# Altium schematic sheet sensor_wifi.SchDoc
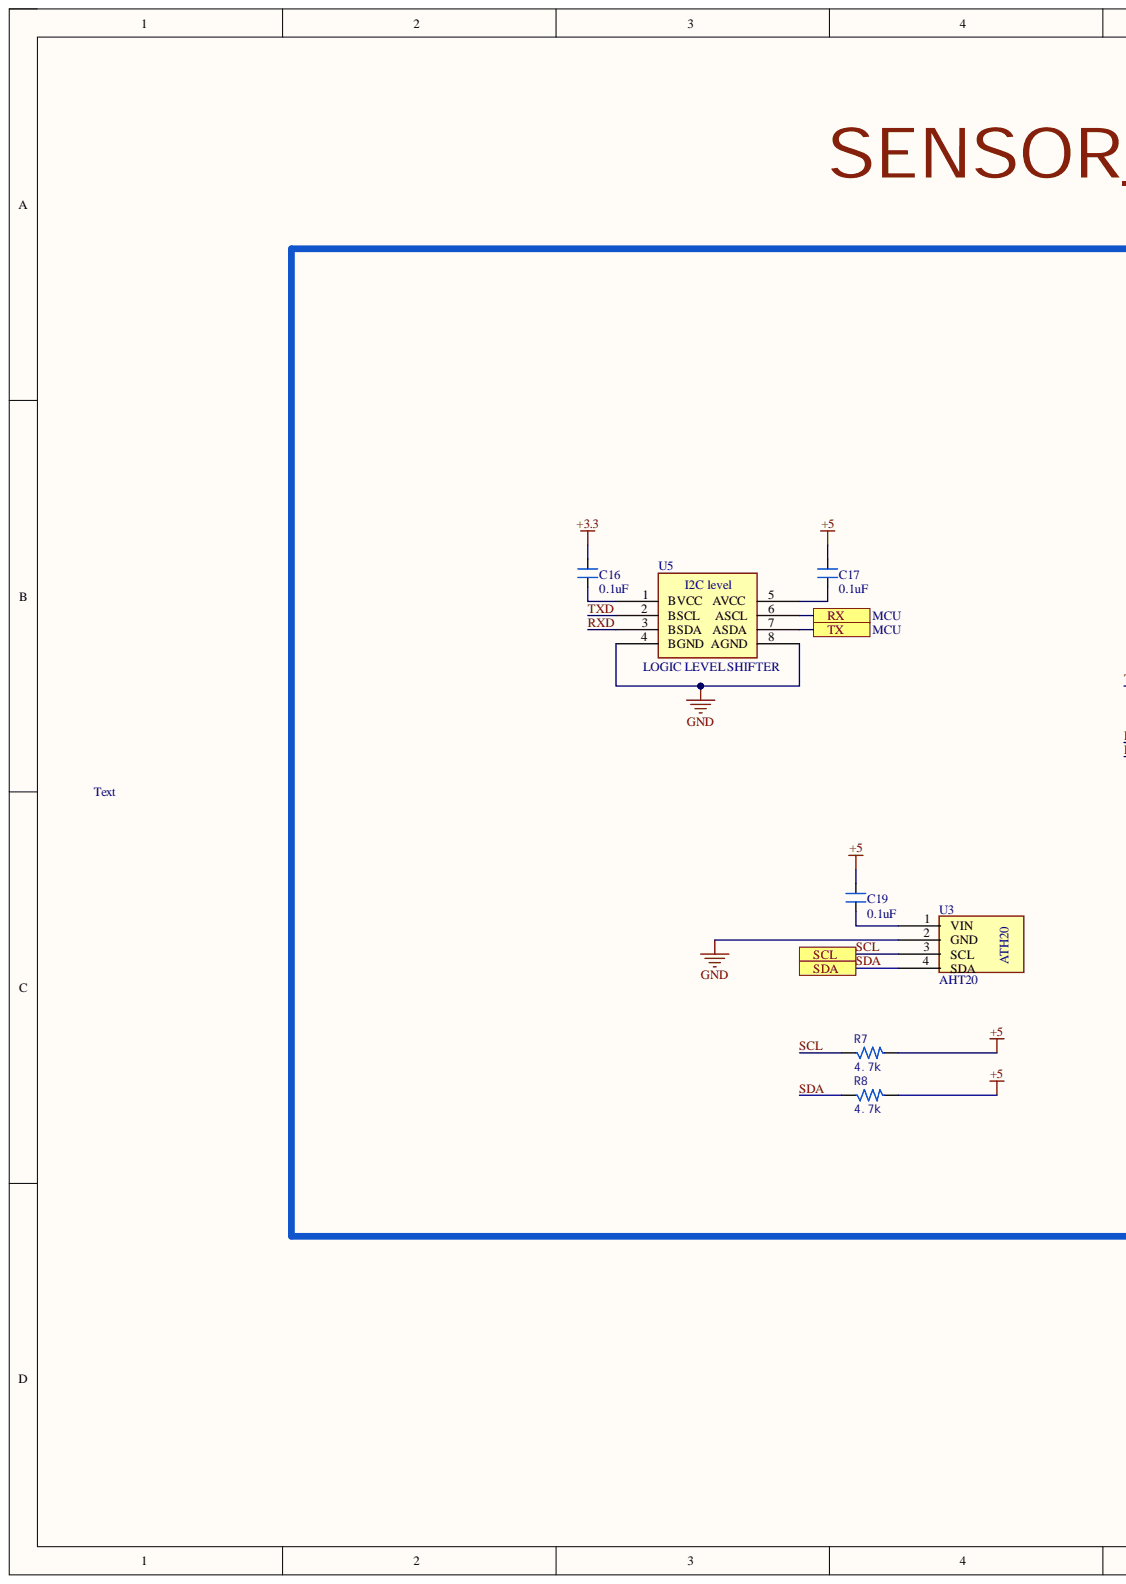
[diagram p0: sensor_wifi.SchDoc, left]
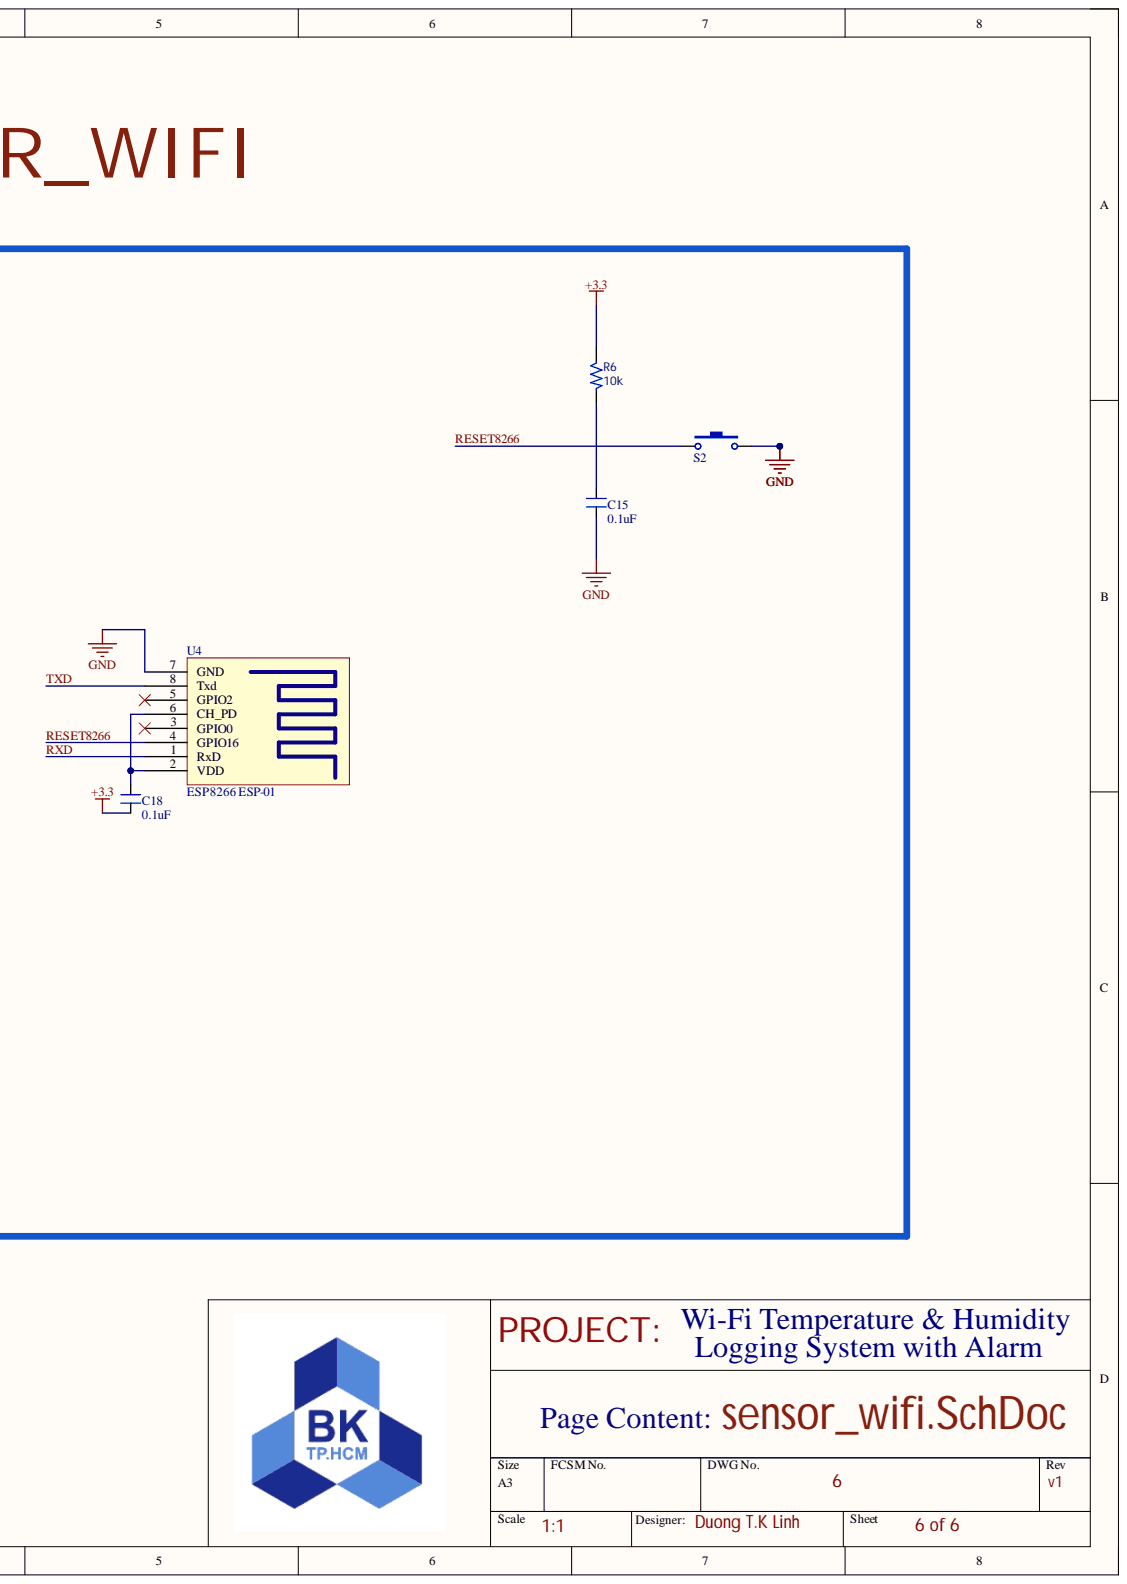
[diagram p1: sensor_wifi.SchDoc, right]

## Parts
C15  0.1uF  [CN2]
C16  0.1uF  [CN2]
C17  0.1uF  [CN2]
C18  0.1uF  [CN2]
C19  0.1uF  [CN2]
R6  10k  [RESISTOR_0603]
R7  4.7k  [RESISTOR_0603]
R8  4.7k  [RESISTOR_0603]
S2  RESET_WIFI  [BUTTON  ROUND]  (value not drawn)
U3  AHT20  [AHT20]
U4  ESP8266 ESP-01  [ESP8266 ESP-01]
U5  LOGIC LEVEL SHIFTER  [I2C LEVEL]

## Ports
RX, SCL, SDA, TX

## Nets
+3.3: C16.2 C18.1 R6.1
+5: C17.2 C19.2 R7.2 R8.2
GND: C15.1 S2.2 U3.2(GND) U4.7(GND) U5.4(BGND) U5.8(AGND)
RESET8266: S2.1 U4.4(GPIO16)
RX: U5.6(ASCL) ; port RX
RXD: U4.1(RxD) U5.3(BSDA)
SCL: R7.1 U3.3(SCL) ; port SCL
SDA: R8.1 U3.4(SDA) ; port SDA
TX: U5.7(ASDA) ; port TX
TXD: U4.8(Txd) U5.2(BSCL)
NetC15_2: C15.2 R6.2
NetC16_1: C16.1 U5.1(BVCC)
NetC17_1: C17.1 U5.5(AVCC)
NetC18_2: C18.2 U4.2(VDD) U4.6(CH_PD)
NetC19_1: C19.1 U3.1(VIN)

Not connected: U4 3(GPIO0) 5(GPIO2)

## Library data of the parts
C15-C19 = CN2: Cap np 104 => 105pF; Component Kind: Standard; Value: 0.1uF; Library Name: Compiled_Devzone.IntLib
R6-R8 = RES-SMD: Component Kind: Standard; Library Name: ID.SchLib; Signal Integrity: Guessed Model
S2 = SW-Button: Switch-Button; Component Kind: Standard; LatestRevisionDate: 17-Jul-2002; LatestRevisionNote: Re-released for DXP Platform.; PackageReference: SPST-2; Published: 24-Mar-1999; Library Name: KhanhBK_Library_4.IntLib; Publisher: Altium Limited
U3 = ATH20: Component Kind: Standard; Library Name: Schlib1.SchLib
U5 = i2c level: Component Kind: Standard; Library Name: Schlib1.SchLib
U4 = ESP8266 ESP-01: Component Kind: Standard; Description: ESP8266 ESP-01; Library Name: KhanhBK_Library_4.IntLib
